# Altium schematic sheet Курсовая.SchDoc(Курсовая)
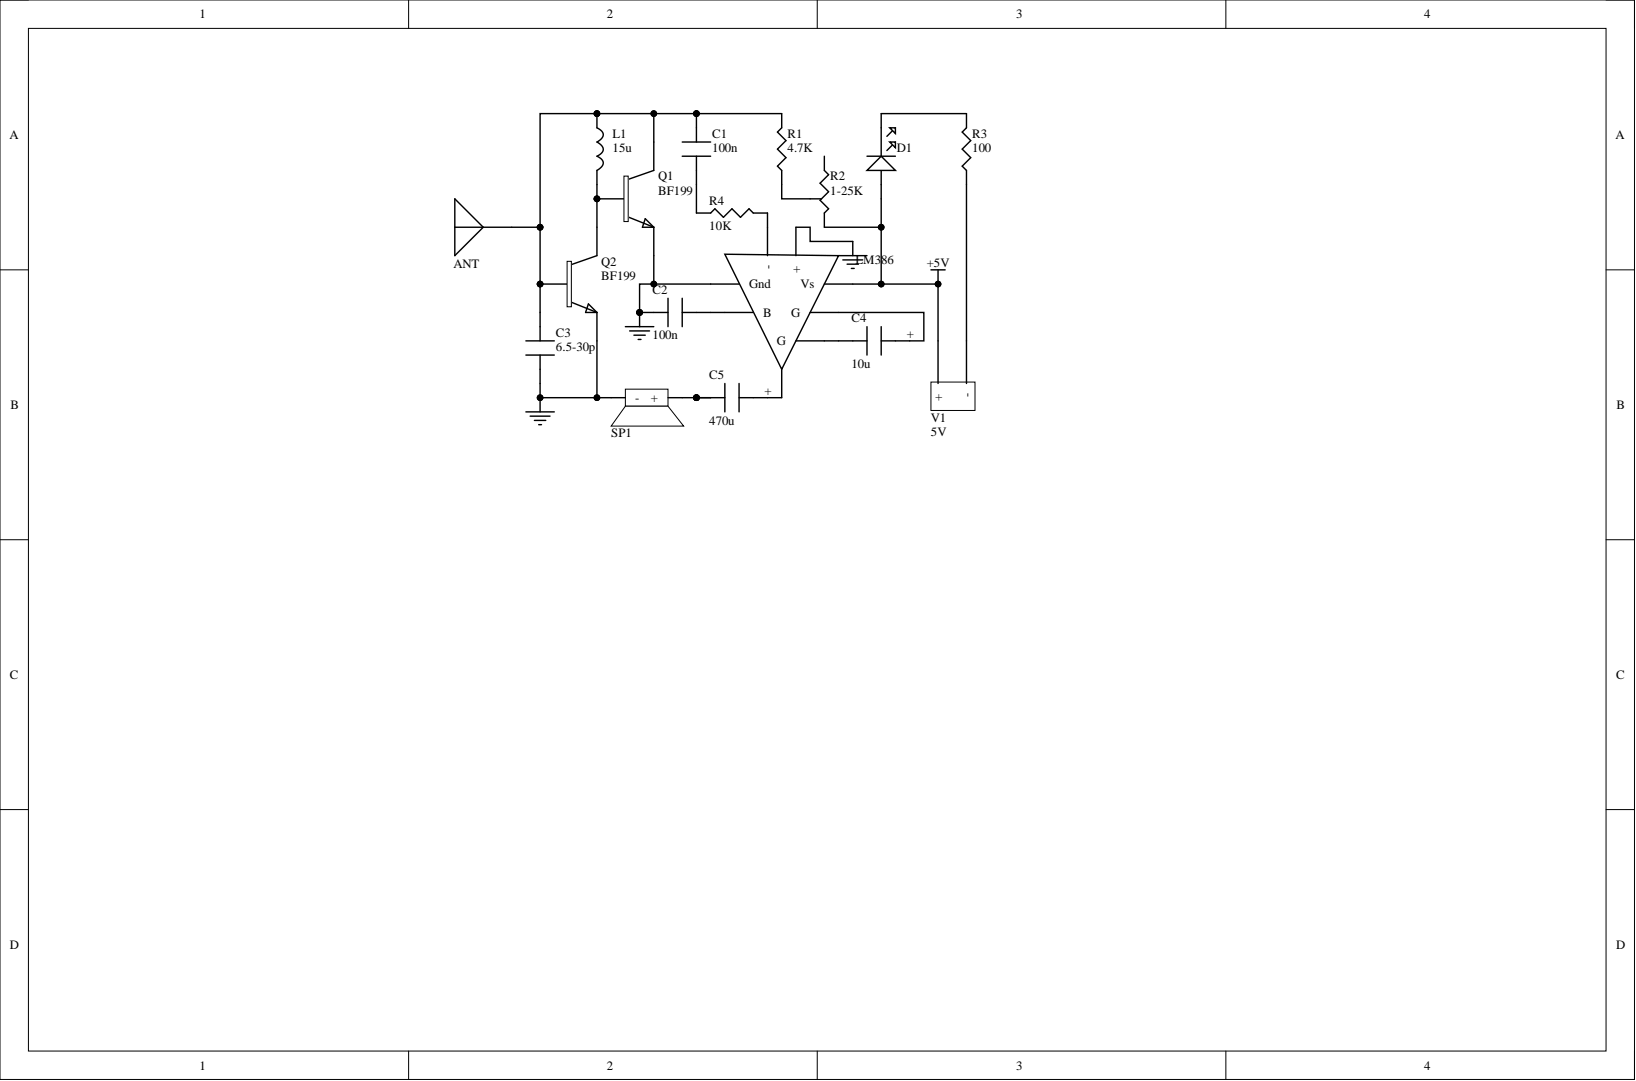
[diagram p0: Курсовая.SchDoc]

## Parts
ANT  ANT  [ANT]
C1  100n  [100n capacitor]
C2  100n  [100n capacitor]
C3  6.5-30p  [6-30pF capacitor]
C4  10u  [10uF capacitor]
C5  470u  [Capacitor 470u]
D1  LTW-M140ZVS  [LED]  (value not drawn)
L1  15u  [15uH inductor]
LM386  LM386N-3  [LM386]  (value not drawn)
Q1  BF199  [BF199]
Q2  BF199  [BF199]
R1  4.7K  [4.7K resistor]
R2  1-25K  [Potentiometer]
R3  100  [100Ohm resistor]
R4  10K  [10K resistor]
SP1  WSP-7704  [Dynamic]  (value not drawn)
V1  5V  [mini-USb port]

## Nets
+5V: D1.2 LM386.6(Vs) R2.2 V1.2
GND: C2.1 C3.1 LM386.3(+) LM386.4(Gnd) Q1.3 Q2.3 SP1.2
NetANT_1: ANT.1 C1.2 C3.2 L1.6 Q1.1 Q2.2 R1.2
NetC1_1: C1.1 R4.1
NetC2_2: C2.2 LM386.7(B)
NetC4_3: C4.3 LM386.8(G)
NetC4_+: C4.+ LM386.1(G)
NetC5_2: C5.2 C5.3 SP1.1
NetC5_+: C5.+ LM386.5
NetD1_1: D1.1 R3.2
NetL1_7: L1.7 Q1.2 Q2.1
NetLM386_2: LM386.2(-) R4.2
NetR1_1: R1.1 R2.3
NetR3_1: R3.1 V1.1

Not connected: R2 1

## Library data of the parts
C1 = CL05A104KP5NNND_1: Cap Ceramic 0.1uF 10V X5R 10% SMD 0402 85C Paper T/R; Manufacturer: Samsung; Capacitance: 100nF; Case/Package: 0402; Category: Ceramic Capacitors; Component Kind: Standard; ComponentLink1Description: https://datasheet.ciiva.com/25744/cl05a104kp5nnnd-25744885.pdf?src-supplier=Digi-Key; ComponentLink1URL: https://datasheet.ciiva.com/25744/cl05a104kp5nnnd-25744885.pdf?src-supplier=Digi-Key; ComponentLink2Description: https://datasheet.ciiva.com/26838/getdatasheetpartid-353245-26838153.pdf?src-supplier=Verical; ComponentLink2URL: https://datasheet.ciiva.com/26838/getdatasheetpartid-353245-26838153.pdf?src-supplier=Verical; Construction: Flat; Depth: 0.5mm; Dielectric: X5R; Height: 0.5mm; Lead Free: Lead Free; Length: 1mm; Manufacturer Part Number: CL05A104KP5NNND; Max Operating Temperature: 85°C; Min Operating Temperature: -55°C; Mount: Surface Mount; Package Quantity: 50000; Packaging: Tape and Reel; Pricing 1: 250000=0,00182 (USD); Radiation Hardening: No; RoHS: Yes; RoHS Compliant: Yes; Series: CL; Supplier 1: Digi-Key; Supplier Part Number 1: CL05A104KP5NNND-ND; Thickness: 0.022inch; Tolerance: 10%; Voltage: 10V; Voltage Rating: 10V; Voltage Rating (DC): 10V; Width: 0.02inch; Library Name: Курсовая.SchLib
C2 = CL05A104KP5NNND: Cap Ceramic 0.1uF 10V X5R 10% SMD 0402 85C Paper T/R; Manufacturer: Samsung; Capacitance: 100nF; Case/Package: 0402; Category: Ceramic Capacitors; Component Kind: Standard; ComponentLink1Description: https://datasheet.ciiva.com/25744/cl05a104kp5nnnd-25744885.pdf?src-supplier=Digi-Key; ComponentLink1URL: https://datasheet.ciiva.com/25744/cl05a104kp5nnnd-25744885.pdf?src-supplier=Digi-Key; ComponentLink2Description: https://datasheet.ciiva.com/26838/getdatasheetpartid-353245-26838153.pdf?src-supplier=Verical; ComponentLink2URL: https://datasheet.ciiva.com/26838/getdatasheetpartid-353245-26838153.pdf?src-supplier=Verical; Construction: Flat; Depth: 0.5mm; Dielectric: X5R; Height: 0.5mm; Lead Free: Lead Free; Length: 1mm; Manufacturer Part Number: CL05A104KP5NNND; Max Operating Temperature: 85°C; Min Operating Temperature: -55°C; Mount: Surface Mount; Package Quantity: 50000; Packaging: Tape and Reel; Pricing 1: 250000=0,00182 (USD); Radiation Hardening: No; RoHS: Yes; RoHS Compliant: Yes; Series: CL; Supplier 1: Digi-Key; Supplier Part Number 1: CL05A104KP5NNND-ND; Thickness: 0.022inch; Tolerance: 10%; Voltage: 10V; Voltage Rating: 10V; Voltage Rating (DC): 10V; Width: 0.02inch; Library Name: Курсовая.SchLib
C3 = GKG30086-05: Cap Trimmer SMD; Manufacturer: Sprague Goodman; Category: Trimmer / Variable Capacitors; Component Kind: Standard; Manufacturer Part Number: GKG30086-05; Pricing 1: 1=1,64000, 10=1,59900, 50=1,56820 (USD); RoHS: Yes; Stock 1: 3567; Supplier 1: Digi-Key; Supplier Part Number 1: SG9122CT-ND; Library Name: Курсовая.SchLib
C4 = 106CKE063M: Aluminum Electrolytic Capacitors - Radial Leaded 10uF 63 Volts 20% LYTICS/IC; Manufacturer: CDE Illinois Capacitor; ESR (Equivalent Series Resistance): 14.9208R; Life (Hours): 2000Hour; Polarity: Polar; Ripple Current: 60mA; Voltage Rating: 63V; Capacitance: 10uF; Case/Package: Radial; Category: Aluminum Electrolytic Capacitors; Component Kind: Standard; ComponentLink1Description: http://www.illinoiscapacitor.com/pdf/seriesDocuments/CKH_CKE%20series.pdf?src-supplier=Digi-Key; ComponentLink1URL: http://www.illinoiscapacitor.com/pdf/seriesDocuments/CKH_CKE%20series.pdf?src-supplier=Digi-Key; Height - Seated (Max): 0.492inch; Lead Pitch: 0.079inch; Manufacturer Part Number: 106CKE063M; Max Operating Temperature: 105°C; Min Operating Temperature: -40°C; Mount: Through Hole; Package Quantity: 1000; Packaging: Bulk; Pricing 1: 10000=0,03278 (USD); RoHS: Yes; RoHS Compliant: Yes; Supplier 1: Digi-Key; Supplier Part Number 1: 106CKE063M-ND; Tolerance: 20%; Library Name: Курсовая.SchLib
C5 = ECA-0JHG471B: Cap Aluminum 470uF 6.3V 20% (6.3 X 11.2mm) Radial 5mm 230mA 1000 hr 105C Ammo Pack; Manufacturer: Panasonic; Lead/Base Style: Straight; Life (Hours): 1000Hour; Capacitance: 470uF; Case/Package: Radial; Category: Aluminum Electrolytic Capacitors; Component Kind: Standard; ComponentLink1Description: https://datasheet.ciiva.com/24067/a-e-461514-24067782.pdf?src-supplier=Mouser; ComponentLink1URL: https://datasheet.ciiva.com/24067/a-e-461514-24067782.pdf?src-supplier=Mouser; ComponentLink2Description: https://datasheet.ciiva.com/106/aba0000ce26-106251.pdf?src-supplier=Digi-Key; ComponentLink2URL: https://datasheet.ciiva.com/106/aba0000ce26-106251.pdf?src-supplier=Digi-Key; ComponentLink3Description: https://datasheet.ciiva.com/11680/aba0000ce26-11680205.pdf?src-supplier=Avnet; ComponentLink3URL: https://datasheet.ciiva.com/11680/aba0000ce26-11680205.pdf?src-supplier=Avnet; Diameter: 6.3mm; Height: 11.2mm; Height - Seated (Max): 0.48inch; Lead Pitch: 5mm; Length: 11.2mm; Manufacturer Part Number: ECA-0JHG471B; Max Operating Temperature: 105°C; Min Operating Temperature: -55°C; Mount: Through Hole; Package Quantity: 2000; Packaging: Tape and Reel; Pricing 1: 4000=0,07820 (USD); Radiation Hardening: No; Ripple Current: 230mA; RoHS: Yes; Supplier 1: Digi-Key; Supplier Part Number 1: ECA-0JHG471B-ND; Termination: Radial; Tolerance: 20%; Voltage Rating: 6.3V; Voltage Rating (DC): 6.3V; Library Name: Курсовая.SchLib
D1 = LTW-M140ZVS: White 120° Viewing Angle 3 x 1.05 x 1.2 mm 3.5 V 20 mA Surface Mount Lamp; Manufacturer: Vishay Lite-On; Forward Current: 20mA; Forward Voltage: 3.2V; Lens Color: Yellow; Lens Style: Rectangular; Max Current Rating: 100mA; Case/Package: SMD/SMT; Category: LEDs; Color: White; Component Kind: Standard; ComponentLink1Description: https://datasheet.ciiva.com/29560/ltw-m140zvs-29560007.pdf?src-supplier=Digi-Key; ComponentLink1URL: https://datasheet.ciiva.com/29560/ltw-m140zvs-29560007.pdf?src-supplier=Digi-Key; ComponentLink2Description: https://datasheet.ciiva.com/24120/ltw-m140zvs-267545-24120598.pdf?src-supplier=Mouser; ComponentLink2URL: https://datasheet.ciiva.com/24120/ltw-m140zvs-267545-24120598.pdf?src-supplier=Mouser; Correlated Color Temperature (CCT): -; Illumination Color: White; Length: 3mm; Manufacturer Part Number: LTW-M140ZVS; Max Operating Temperature: 85°C; Min Operating Temperature: -30°C; Orientation: Top View; Package Quantity: 2000; Packaging: Tape and Reel; Pricing 1: 1=0,45000, 10=0,25000, 50=0,25000, 100=0,11500, 1000=0,08300, 10000=0,05800 (USD); RoHS: Yes; RoHS Compliant: Yes; Stock 1: 14030; Supplier 1: Mouser; Supplier Part Number 1: 859-LTW-M140ZVS; Test Current: 20mA; Viewing Angle: 120°; Width: 1.4mm; Library Name: Курсовая.SchLib
L1 = 78F150J-RC: BOURNS JW MILLER   78F150J-RC   CHOKE, 15UH, 150MA, 5%, 16MHZ; Core Material: Ferrite; Current Rating: 335mA; DC Resistance (DCR): 930mR; Lead Diameter: 0.51mm; Lead/Base Style: Axial; Q Factor: 50; Shielding: Unshielded; Test Frequency: 2.5MHz; Case/Package: Axial; Category: Fixed Inductors; Component Kind: Standard; ComponentLink1Description: https://datasheet.ciiva.com/8105/78f-series-8105197.pdf?src-supplier=Avnet; ComponentLink1URL: https://datasheet.ciiva.com/8105/78f-series-8105197.pdf?src-supplier=Avnet; ComponentLink2Description: https://datasheet.ciiva.com/1474/78f-series-1474693.pdf?src-supplier=Element14; ComponentLink2URL: https://datasheet.ciiva.com/1474/78f-series-1474693.pdf?src-supplier=Element14; ComponentLink3Description: https://datasheet.ciiva.com/6703/78f-series-6703437.pdf?src-supplier=Digi-Key; ComponentLink3URL: https://datasheet.ciiva.com/6703/78f-series-6703437.pdf?src-supplier=Digi-Key; Contact Plating: Tin; DC Current: 335mA; Diameter: 2.79mm; Inductance: 15uH; Inductance Tolerance: 5%; Length: 7.11mm; Manufacturer: Bourns JW Miller; Manufacturer Part Number: 78F150J-RC; Max DC Current: 335mA; Max Operating Temperature: 105°C; Military Standard: Not; Min Operating Temperature: -55°C; Mount: Through Hole; Package Quantity: 5000; Packaging: Bag; Pricing 1: 1=0,21000, 10=0,15600, 50=0,14200, 100=0,13900, 1000=0,09100, 10000=0,07900 (USD); REACH SVHC: No SVHC; Resistor Type: Wirewound; RoHS: Yes; RoHS Compliant: Yes; Self Resonant Frequency: 16MHz; Series: 78F; Stock 1: 2871; Supplier 1: Mouser; Supplier Part Number 1: 542-78F150J-RC; Termination: Axial; Tolerance: 5%; Library Name: Курсовая.SchLib
LM386 = LM386N-3: Audio Amp Speaker 1-CH Mono 0.7W Class-AB 8-Pin PDIP Rail; Manufacturer: TI National Semiconductor; Amplifier Type: POWER; Bandwidth: 300kHz; Input Bias Current: 250nA; Input Offset Voltage (Vos): 2.5mV; Load Impedance: 8R; Max Output Power: 700mW; Max Power Dissipation: 1.25W; Max Supply Voltage: 12V; Min Supply Voltage: 4V; Nominal Supply Current: 4mA; Number of Amplifiers: 1; Output Power: 1W; Power Supply Rejection Ratio (PSRR): 50dB; Quiescent Current: 8mA; Supply Type: Single; Voltage Gain: 46dB; Case/Package: P; Category: Amplifiers - Audio; Component Kind: Standard; ComponentLink1Description: https://datasheet.ciiva.com/2968/lm386-2968336.pdf?src-supplier=Avnet; ComponentLink1URL: https://datasheet.ciiva.com/2968/lm386-2968336.pdf?src-supplier=Avnet; ComponentLink2Description: https://datasheet.ciiva.com/15131/getdatasheetpartid-186304-15131754.pdf?src-supplier=Verical; ComponentLink2URL: https://datasheet.ciiva.com/15131/getdatasheetpartid-186304-15131754.pdf?src-supplier=Verical; ComponentLink3Description: https://datasheet.ciiva.com/26842/lm386n-3-26842542.pdf?src-supplier=IIIC; ComponentLink3URL: https://datasheet.ciiva.com/26842/lm386n-3-26842542.pdf?src-supplier=IIIC; ComponentLink4Description: https://datasheet.ciiva.com/1480/1640767-1480995.pdf?src-supplier=Element14; ComponentLink4URL: https://datasheet.ciiva.com/1480/1640767-1480995.pdf?src-supplier=Element14; ComponentLink5Description: https://datasheet.ciiva.com/6625/lm386-6625686.pdf?src-supplier=Digi-Key; ComponentLink5URL: https://datasheet.ciiva.com/6625/lm386-6625686.pdf?src-supplier=Digi-Key; Gain Bandwidth Product: 300kHz; Height: 3.3mm; Lead Free: Contains Lead; Length: 9.27mm; Manufacturer Part Number: LM386N-3; Max Operating Temperature: 70°C; Min Operating Temperature: 0°C; Number of Channels: 1; Number of Pins: 8; Package Quantity: 40; Packaging: Rail/Tube; Power Dissipation: 1.25W; Radiation Hardening: No; REACH SVHC: No SVHC; RoHS: No; RoHS Compliant: No; Series: LM386; Supplier 1: Digi-Key; Supplier Part Number 1: 2156-LM386N-3-ND; Supply Current: 4mA; Unity Gain Bandwidth Product: 300kHz; Weight: 0.5lb; Width: 6.35mm; Library Name: Курсовая.SchLib
Q1 Q2 = BF199: TRANSISTOR RF NPN 25V 50MA TO-92; Manufacturer: ON Semiconductor / Fairchild; Collector Base Voltage (VCBO): 40V; Element Configuration: Single; Emitter Base Voltage (VEBO): 4V; Frequency: 1.1GHz; hFE Min: 38; Max Power Dissipation: 350mW; Number of Elements: 1; Case/Package: TO-92; Category: BJTs; Collector Emitter Breakdown Voltage: 25V; Collector Emitter Voltage (VCEO): 25V; Component Kind: Standard; ComponentLink1Description: https://datasheet.ciiva.com/965/bf199-965939.pdf?src-supplier=Digi-Key; ComponentLink1URL: https://datasheet.ciiva.com/965/bf199-965939.pdf?src-supplier=Digi-Key; ComponentLink2Description: https://datasheet.ciiva.com/1196/200356-1196561.pdf?src-supplier=Element14; ComponentLink2URL: https://datasheet.ciiva.com/1196/200356-1196561.pdf?src-supplier=Element14; ComponentLink3Description: https://datasheet.ciiva.com/1196/200356-1196562.pdf?src-supplier=Element14; ComponentLink3URL: https://datasheet.ciiva.com/1196/200356-1196562.pdf?src-supplier=Element14; ComponentLink4Description: https://datasheet.ciiva.com/1217/200356-1217723.pdf?src-supplier=Farnell; ComponentLink4URL: https://datasheet.ciiva.com/1217/200356-1217723.pdf?src-supplier=Farnell; Continuous Collector Current: 50mA; Current Rating: 50mA; Gain Bandwidth Product: 1.1GHz; Lead Free: Lead Free; Manufacturer Part Number: BF199; Max Collector Current: 50mA; Max Frequency: 1.1GHz; Max Operating Temperature: 150°C; Min Operating Temperature: -55°C; Mount: Through Hole; Number of Pins: 3; Package Quantity: 2000; Packaging: Bulk; Polarity: NPN; Power Dissipation: 350mW; REACH SVHC: No SVHC; RoHS: No; Supplier 1: Digi-Key; Supplier Part Number 1: BF199FS-ND; Transition Frequency: 1.1GHz; Voltage Rating (DC): 25V; Weight: 0.202g; Library Name: Курсовая.SchLib
R1 = SG731JTTD472M: Thick Film Resistors - SMD 0.1W 4.7Kohm 20% 200ppm; Manufacturer: KOA Speer; Power Rating: 100mW; Resistance: 4.7kR; Temperature Coefficient: 200ppm/°C; Voltage Rating: 50V; Case Code (Imperial): 0603; Case Code (Metric): 1608; Case/Package: 0603; Category: Chip SMD Resistors; Component Kind: Standard; ComponentLink1Description: http://www.koaspeer.com/catimages/Products/SG73/SG73.pdf?src-supplier=Mouser; ComponentLink1URL: http://www.koaspeer.com/catimages/Products/SG73/SG73.pdf?src-supplier=Mouser; Height: 0.45mm; Length: 1.6mm; Manufacturer Part Number: SG731JTTD472M; Max Operating Temperature: 155°C; Min Operating Temperature: -55°C; Package Quantity: 5000; Packaging: Tape and Reel; Pricing 1: 5000=0,01564 (USD); Resistor Type: Thick Film; RoHS: Yes; RoHS Compliant: Yes; Series: SG73; Supplier 1: Digi-Key; Supplier Part Number 1: 2019-SG731JTTD472MTR-ND; Termination: SMD/SMT; Tolerance: 20%; Voltage Rating (DC): 50V; Width: 0.8mm; Library Name: Курсовая.SchLib
R2 = CB6MH253M: Res Carbon Film Trimmer 25K Ohm 20% 0.15W 1(Elec)/1(Mech)Turn (9.8 X 5 X 12.1mm) Pin Thru-Hole; Manufacturer: TE Connectivity Citec; Power Rating: 100mW; Resistance: 25kR; Sealable: No (Without); Category: Variable Resistors and Potentiometers; Component Kind: Standard; ELV: Compliant; Manufacturer Part Number: CB6MH253M; Mount: Radial; Pricing 1: 1000=0,62682 (USD); RoHS: Yes; RoHS Compliant: Yes; Supplier 1: Digi-Key; Supplier Part Number 1: CB6MH253M-ND; Tolerance: 20%; Library Name: Курсовая.SchLib
R3 = SR0805MR-7W100RL: Res 100 Ohm 20% 1/4W 0805; Manufacturer: Yageo; Max Power Dissipation: 250mW; Resistance: 100R; Temperature Coefficient: 200ppm/°C; Case/Package: 0805; Category: Chip SMD Resistors; Component Kind: Standard; ComponentLink1Description: http://www.yageo.com/documents/recent/PYu-SR_20105_RoHS_L_5.pdf?src-supplier=Digi-Key; ComponentLink1URL: http://www.yageo.com/documents/recent/PYu-SR_20105_RoHS_L_5.pdf?src-supplier=Digi-Key; Features: Automotive AEC-Q200; Height: 0.024inch; Length: 0.079inch; Manufacturer Part Number: SR0805MR-7W100RL; Max Operating Temperature: 155°C; Min Operating Temperature: -55°C; Number of Terminations: 2; Packaging: Tape and Reel; Pricing 1: 5000=0,02251 (USD); Resistor Type: Thick Film; RoHS: Yes; Supplier 1: Digi-Key; Supplier Part Number 1: SR0805MR-7W100RL-ND; Tolerance: 20%; Width: 0.049inch; Library Name: Курсовая.SchLib
R4 = FCR0603MT10K0: Res Thick Film 0603 10kOhm 20% 1/10W ±200ppm/°C Molded Paper T/R; Manufacturer: Stackpole Electronics; Component Kind: Standard; ComponentLink1Description: http://datasheet.octopart.com/FCR0603MT10K0-Stackpole-Electronics-datasheet-141158313.pdf?src-supplier=Stackpole+Electronics; ComponentLink1URL: http://datasheet.octopart.com/FCR0603MT10K0-Stackpole-Electronics-datasheet-141158313.pdf?src-supplier=Stackpole+Electronics; Manufacturer Part Number: FCR0603MT10K0; Pricing 1: 5000=0,01250 (USD); RoHS Compliant: Yes; Supplier 1: Digi-Key; Supplier Part Number 1: FCR0603MT10K0-ND; Library Name: Курсовая.SchLib
SP1 = WSP-7704: Manufacturer: Soberton; Impedance: 4R; Max Power Dissipation: 4W; Power Rating: 3W; Ratings: IP65,Waterproof,Dust Tight; Self Resonant Frequency: 330Hz; Sensing Method: Magnetic; Shape: Rectangular,Round; Category: Speakers; Component Kind: Standard; ComponentLink1Description: http://cirlw3yrzg4afc1x47lv2k18.wpengine.netdna-cdn.com/wp-content/uploads/2017/12/WSP-7704.pdf?src-supplier=Digi-Key; ComponentLink1URL: http://cirlw3yrzg4afc1x47lv2k18.wpengine.netdna-cdn.com/wp-content/uploads/2017/12/WSP-7704.pdf?src-supplier=Digi-Key; Manufacturer Part Number: WSP-7704; Packaging: Tray; Pricing 1: 1=3,48000, 10=2,87400, 25=2,65240, 40=2,49075, 100=2,33570, 500=2,06150 (USD); RoHS: Yes; Stock 1: 1122; Supplier 1: Digi-Key; Supplier Part Number 1: 433-1182-ND; Termination: Solder; Type: General Purpose; Library Name: Курсовая.SchLib
V1 = M701-340542: USB Connectors MINI USB SINGLE SMT 5P HORIZONTAL; Manufacturer: Harwin; Connector Type: USB,Receptacle; Current Rating: 1A; Gender: Receptacle; Insulation Resistance: 100MR; Number of Contacts: 5; Number of Ports: 1; Pitch: 0.8mm; Category: Connectors; Color: Black; Component Kind: Standard; ComponentLink1Description: https://datasheet.ciiva.com/4599/m701-340542-53541-4599048.pdf?src-supplier=Mouser; ComponentLink1URL: https://datasheet.ciiva.com/4599/m701-340542-53541-4599048.pdf?src-supplier=Mouser; ComponentLink2Description: https://datasheet.ciiva.com/3095/m701-340542-3095669.pdf?src-supplier=Avnet; ComponentLink2URL: https://datasheet.ciiva.com/3095/m701-340542-3095669.pdf?src-supplier=Avnet; Contact Material: Bronze; Contact Plating: Gold; Depth: 9.3mm; Housing Material: Polymer; Length: 9.9mm; Manufacturer Part Number: M701-340542; Max Current Rating: 1A; Max Operating Temperature: 105°C; Min Operating Temperature: -55°C; Mount: Surface Mount,Horizontal; Number of Positions: 5; Number of Terminals/Pins: 5; Orientation: Right Angle; Package Quantity: 68; Packaging: Bulk; Pricing 1: 1=1,23000, 10=1,07900, 25=1,01520, 50=0,97300, 100=0,93060, 250=0,84600, 500=0,76140, 1000=0,67680, 2500=0,61335 (USD); RoHS: Yes; RoHS Compliant: Yes; Series: M701; Stock 1: 89; Supplier 1: Digi-Key; Supplier Part Number 1: 952-2197-ND; Termination: Solder; Library Name: Курсовая.SchLib
ANT = ANT: Component Kind: Standard; Library Name: Курсовая.SchLib
